# Altium schematic sheet CAN.SchDoc(CAN)
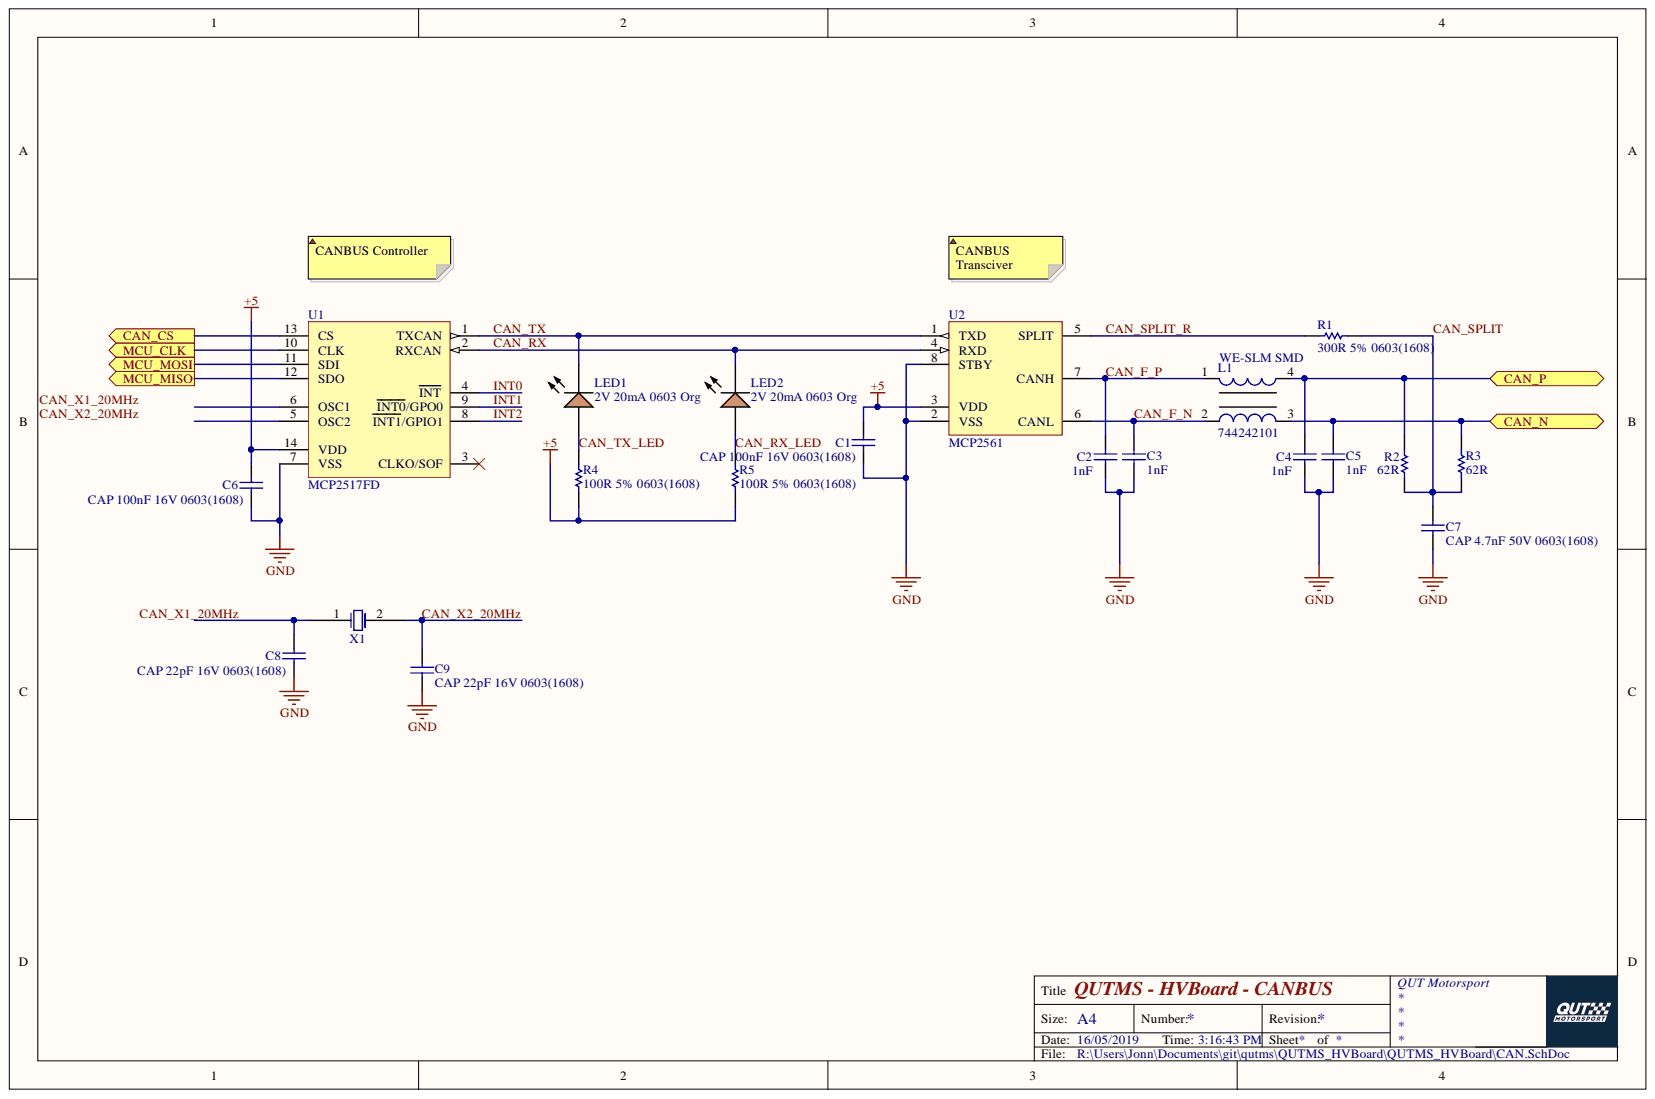
[diagram p0: CAN.SchDoc]

## Parts
C1  CAP 100nF 16V 0603(1608)  value 100nF  Case-EIA: 0603  [CAPC0603(1608)100_N]
C2  1nF  [CAPC0603(1608)100_M]
C3  1nF  [CAPC0603(1608)100_M]
C4  1nF  [CAPC0603(1608)100_M]
C5  1nF  [CAPC0603(1608)100_M]
C6  CAP 100nF 16V 0603(1608)  value 100nF  [CAPC0603(1608)100_N]
C7  CAP 4.7nF 50V 0603(1608)  value 4.7nF  [CAPC0603(1608)100_M]
C8  CAP 22pF 16V 0603(1608)  value 22pF  [CAPC0603(1608)100_N]
C9  CAP 22pF 16V 0603(1608)  value 22pF  [CAPC0603(1608)100_N]
L1  744242101  [WE-SLM]
LED1  2V 20mA 0603 Org  [KING-LED0603-25-ORANGE_V]
LED2  2V 20mA 0603 Org  [KING-LED0603-25-ORANGE_V]
R1  300R 5% 0603(1608)  [RESC0603(1608)_N]
R2  62R  [RESC1210(3225)_N]
R3  62R  [RESC1210(3225)_N]
R4  100R 5% 0603(1608)  [RESC0603(1608)_L]
R5  100R 5% 0603(1608)  [RESC0603(1608)_L]
U1  MCP2517FD  [MCP2517FD SOIC]
U2  MCP2561  [MCP2561 SOIC]
X1  Crystal 20MHz  [Crystal 20MHz]  (value not drawn)

## Ports
CAN_CS, CAN_N, CAN_P, MCU_CLK, MCU_MISO, MCU_MOSI

## Nets
+5: C1.2 C6.2 R4.2 R5.2 U1.14(VDD) U2.3(VDD)
GND: C1.1 C2.1 C3.1 C4.1 C5.1 C6.1 C7.1 C8.1 C9.2 U1.7(VSS) U2.2(VSS) U2.8(STBY)
CAN_CS: U1.13(CS) ; port CAN_CS
CAN_F_N: C3.2 L1.2 U2.6(CANL)
CAN_F_P: C2.2 L1.1 U2.7(CANH)
CAN_N: C5.2 L1.3 R3.2 ; port CAN_N
CAN_P: C4.2 L1.4 R2.2 ; port CAN_P
CAN_RX: LED2.2 U1.2(RXCAN) U2.4(RXD)
CAN_RX_LED: LED2.1 R5.1
CAN_SPLIT: C7.2 R1.2 R2.1 R3.1
CAN_SPLIT_R: R1.1 U2.5(SPLIT)
CAN_TX: LED1.2 U1.1(TXCAN) U2.1(TXD)
CAN_TX_LED: LED1.1 R4.1
CAN_X1_20MHz: C8.2 U1.6(OSC1) X1.1
CAN_X2_20MHz: C9.1 U1.5(OSC2) X1.2
INT0: U1.4(INT)
INT1: U1.9(INT0/GPO0)
INT2: U1.8(INT1/GPIO1)
MCU_CLK: U1.10(CLK) ; port MCU_CLK
MCU_MISO: U1.12(SDO) ; port MCU_MISO
MCU_MOSI: U1.11(SDI) ; port MCU_MOSI

Not connected: U1 3(CLKO/SOF)

## Library data of the parts
C1 = CMP-1035-02584-1: CAP 100nF 16V ±10% 0603 (1608 Metric) Thickness 1mm SMD; Tolerance: Â±10%; Case-Metric: 1608; Value: 100nF; Technology: SMT; Rated Voltage: 16 V; Max Thickness: 1 mm; Library Name: Altium Content Vault; Component Kind: Standard
C2-C5 = CMP-1035-01878-1: CAP 1nF 16V ±5% 0603 (1608 Metric) Thickness 1mm SMD; Tolerance: Â±5%; Case-Metric: 1608; Case-EIA: 0603; Value: 1nF; Technology: SMT; Rated Voltage: 16 V; Max Thickness: 1 mm; Library Name: Altium Content Vault; Component Kind: Standard
C6 = CMP-1035-02584-1: CAP 100nF 16V ±10% 0603 (1608 Metric) Thickness 1mm SMD; Tolerance: Â±10%; Case-Metric: 1608; Case-EIA: 0603; Technology: SMT; Rated Voltage: 16 V; Max Thickness: 1 mm; Library Name: Altium Content Vault; Component Kind: Standard
C7 = CMP-1035-02202-1: CAP 4.7nF 50V ±5% 0603 (1608 Metric) Thickness 1mm SMD; Tolerance: Â±5%; Case-Metric: 1608; Case-EIA: 0603; Technology: SMT; Rated Voltage: 50 V; Max Thickness: 1 mm; Library Name: Altium Content Vault; Component Kind: Standard
C8 C9 = CMP-1035-00808-1: CAP 22pF 16V ±5% 0603 (1608 Metric) Thickness 1mm SMD; Tolerance: Â±5%; Case-Metric: 1608; Case-EIA: 0603; Technology: SMT; Rated Voltage: 16 V; Max Thickness: 1 mm; Library Name: Altium Content Vault; Component Kind: Standard
L1 = CMP-0222-00021-1: SMD Common Mode Line Filter WE-SLM, L = 100 µH; Inductance: 100 ÂµH; PackageVersion: 2006; PackageReference: WE-SLM; PackageDescription: SMD Inductor, 4-Leads, Body 3.6 x 6.3 mm; Library Name: Altium Content Vault; DatasheetVersion: ver 3, Feb-2006; ComponentLink2URL: http://www.we-online.de/katalog/media/pdf/744242101.pdf; ComponentLink2Description: Datasheet; ComponentLink1URL: http://www.we-online.com; ComponentLink1Description: Manufacturer Link; Component Kind: Standard
LED1 LED2 = CMP-0239-00002-1: LED, SMT, 0603(1608), 0.25mm Tthickness, Super Bright Orange; PartNumber: APG1608SEKC/T; Reverse Voltage: 5 V; Power Dissipation: 75 mW; Packing: Reel; Forward Current: 30 mA; Storage Temperature: -40 to 85 degC; PackageVersion: Rev. 4A, 12/2011; PackageReference: LED0603-25-ORANGE; PackageDescription: 2-Pin SMT LED, 1.6 x 0.8 mm Body, 0.25mm Thickness; Mounting Technology: Surface Mount; Library Name: Altium Content Vault; DatasheetVersion: Rev. 4A, 12/2011; ComponentLink2URL: http://www.kingbrightusa.com/images/catalog/SPEC/APG1608SEKC-T.pdf; ComponentLink2Description: Datasheet; ComponentLink1URL: http://www.kingbrightusa.com/; ComponentLink1Description: Manufacturer URL; Component Kind: Standard
R1 = CMP-1012-00061-1: 300R 0.1W 5% 0603 (1608 Metric)  SMD; Value: 300.00 Ohm; Case-Metric: 1608; Case-EIA: 0603; Tolerance: 5 %; Technology: SMT; Power: 0.1 W; Library Name: Altium Content Vault; Component Kind: Standard
R2 R3 = CMP-1015-00045-1: 62R 0.5W 5% 1210 (3225 Metric)  SMD; Value: 62.00 Ohm; Case-Metric: 3225; Case-EIA: 1210; Tolerance: 5 %; Technology: SMT; Power: 0.5 W; Library Name: Altium Content Vault; Component Kind: Standard
R4 R5 = CMP-1012-00050-1: 100R 0.1W 5% 0603 (1608 Metric)  SMD; Value: 100.00 Ohm; Case-Metric: 1608; Case-EIA: 0603; Tolerance: 5 %; Technology: SMT; Power: 0.1 W; Library Name: Altium Content Vault; Component Kind: Standard
U1 = MCP2517FD: Component Kind: Standard; Library Name: QUTMS_CANLibrary.SchLib
U2 = MCP2561: Component Kind: Standard; Library Name: QUTMS_CANLibrary.SchLib
X1 = Crystal 20MHz: ComponentLink1URL: http://cfd.citizen.co.jp/english/prod-tech/product/pdf/datasheet_AT/HCM49_E.pdf; ComponentLink1Description: Datasheet; Component Kind: Standard; Library Name: QUTMS_CrystalLibrary.SchLib
